# Altium schematic sheet Multiplexage_Matrices.SchDoc
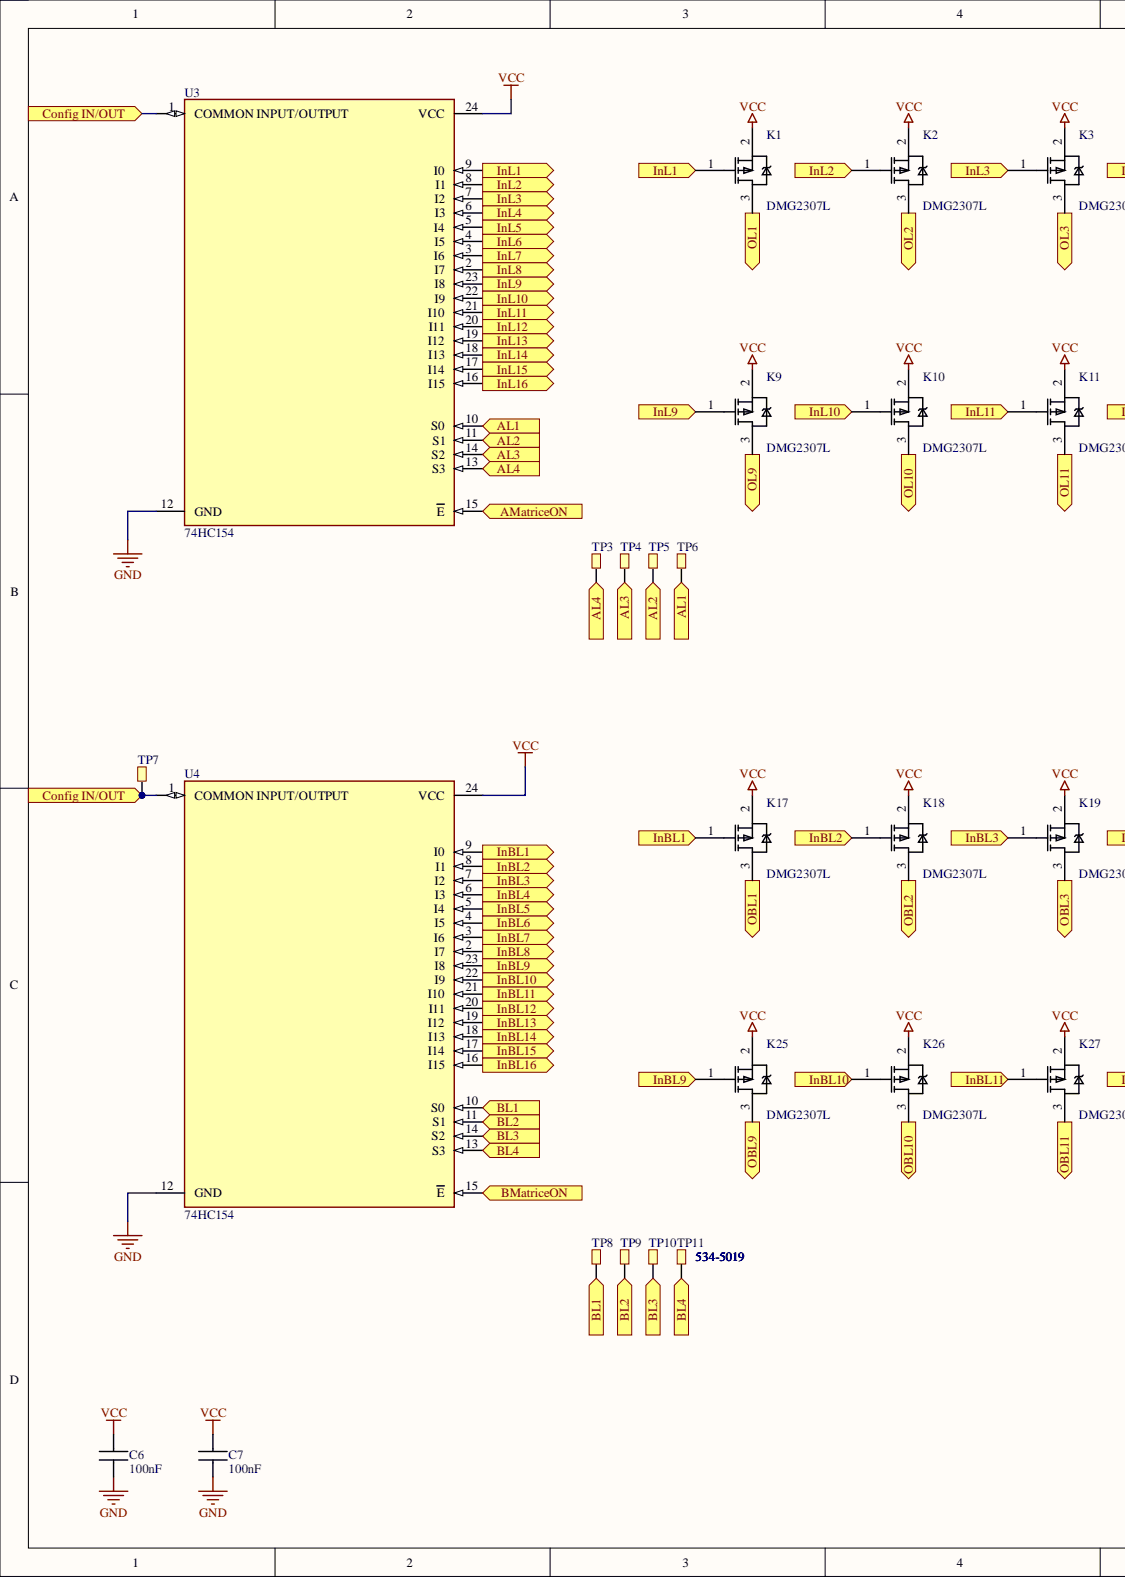
[diagram p0: Multiplexage_Matrices.SchDoc, left]
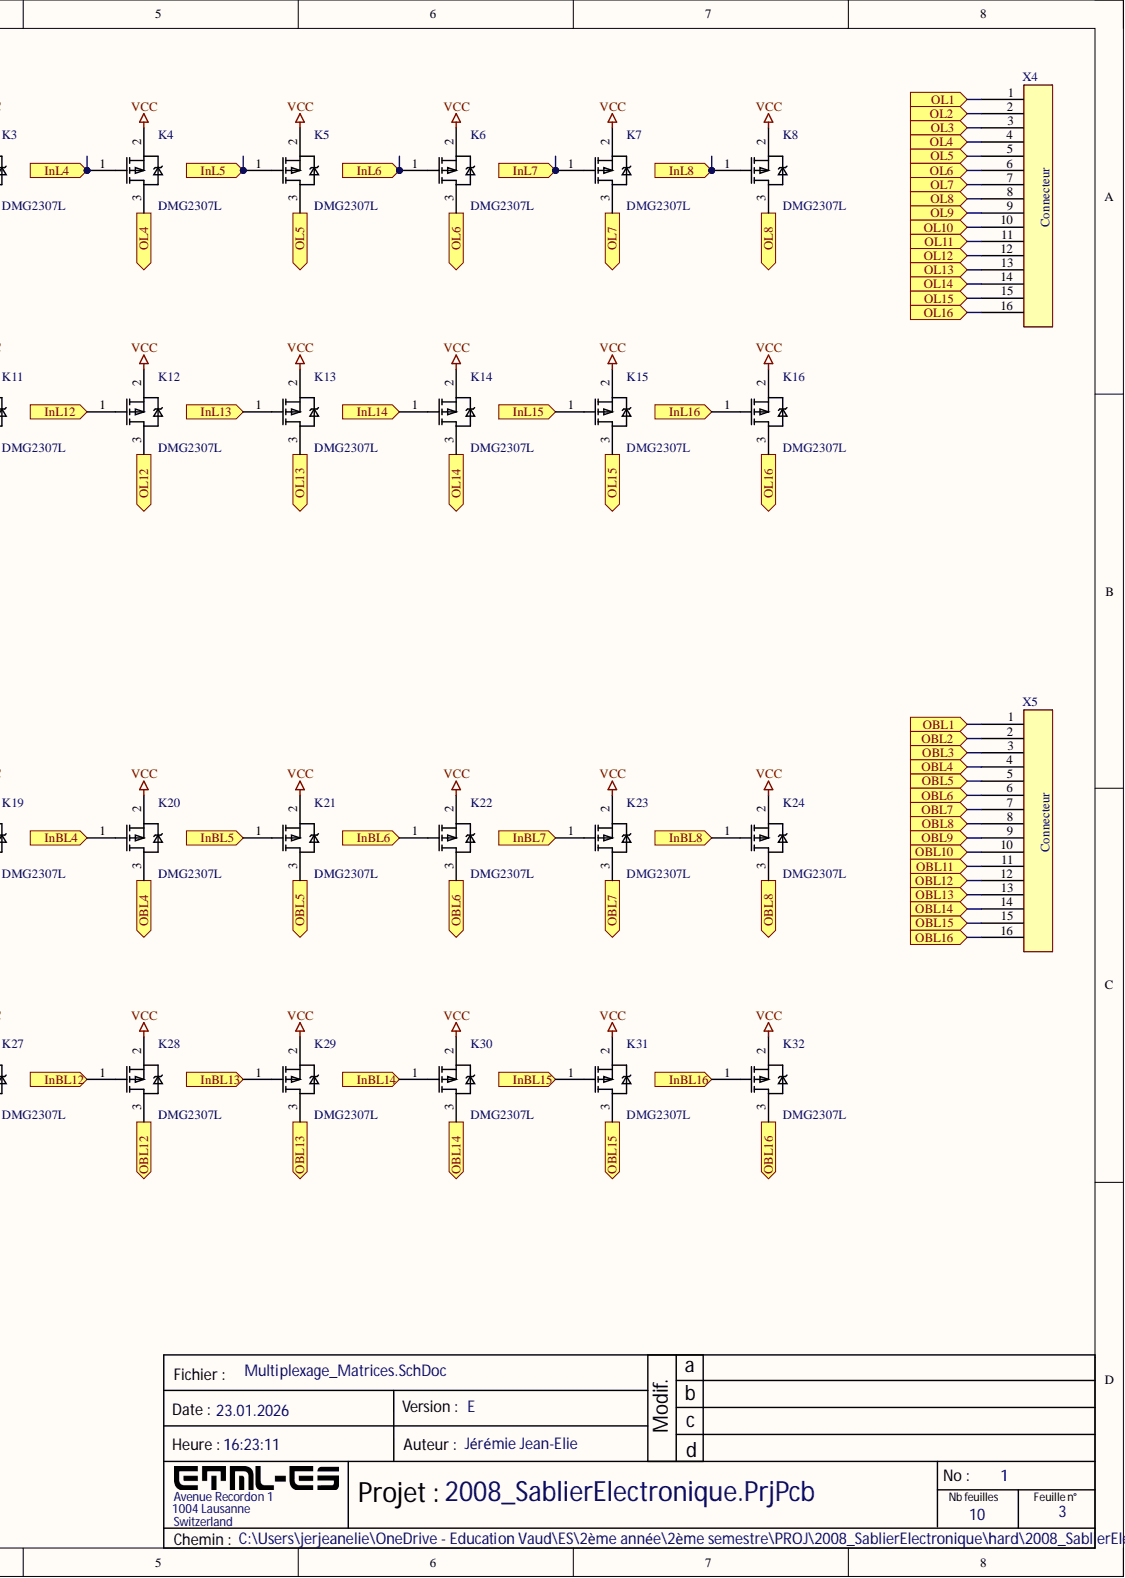
[diagram p1: Multiplexage_Matrices.SchDoc, right]

## Parts
C6  100nF  [CAPC1608X08M]
C7  100nF  [CAPC1608X08M]
K1  DMG2307L  [SOT90P240X110-3M]
K2  DMG2307L  [SOT90P240X110-3M]
K3  DMG2307L  [SOT90P240X110-3M]
K4  DMG2307L  [SOT90P240X110-3M]
K5  DMG2307L  [SOT90P240X110-3M]
K6  DMG2307L  [SOT90P240X110-3M]
K7  DMG2307L  [SOT90P240X110-3M]
K8  DMG2307L  [SOT90P240X110-3M]
K9  DMG2307L  [SOT90P240X110-3M]
K10  DMG2307L  [SOT90P240X110-3M]
K11  DMG2307L  [SOT90P240X110-3M]
K12  DMG2307L  [SOT90P240X110-3M]
K13  DMG2307L  [SOT90P240X110-3M]
K14  DMG2307L  [SOT90P240X110-3M]
K15  DMG2307L  [SOT90P240X110-3M]
K16  DMG2307L  [SOT90P240X110-3M]
K17  DMG2307L  [SOT90P240X110-3M]
K18  DMG2307L  [SOT90P240X110-3M]
K19  DMG2307L  [SOT90P240X110-3M]
K20  DMG2307L  [SOT90P240X110-3M]
K21  DMG2307L  [SOT90P240X110-3M]
K22  DMG2307L  [SOT90P240X110-3M]
K23  DMG2307L  [SOT90P240X110-3M]
K24  DMG2307L  [SOT90P240X110-3M]
K25  DMG2307L  [SOT90P240X110-3M]
K26  DMG2307L  [SOT90P240X110-3M]
K27  DMG2307L  [SOT90P240X110-3M]
K28  DMG2307L  [SOT90P240X110-3M]
K29  DMG2307L  [SOT90P240X110-3M]
K30  DMG2307L  [SOT90P240X110-3M]
K31  DMG2307L  [SOT90P240X110-3M]
K32  DMG2307L  [SOT90P240X110-3M]
TP3  5019  [TESTPOINT_SMD]  (value not drawn)
TP4  5019  [TESTPOINT_SMD]  (value not drawn)
TP5  5019  [TESTPOINT_SMD]  (value not drawn)
TP6  5019  [TESTPOINT_SMD]  (value not drawn)
TP7  5019  [TESTPOINT_SMD]  (value not drawn)
TP8  5019  [TESTPOINT_SMD]  (value not drawn)
TP9  5019  [TESTPOINT_SMD]  (value not drawn)
TP10  5019  [TESTPOINT_SMD]  (value not drawn)
TP11  5019  Supplier Part Number 1: 534-5019  [TESTPOINT_SMD]
U3  74HC154  [SOIC24]
U4  74HC154  [SOIC24]
X4  (no value)  [CON8x8(edited)]
X5  (no value)  [CON8x8(edited)]

## Ports
AL1, AL2, AL3, AL4, AMatriceON, BL1, BL2, BL3, BL4, BMatriceON, Config IN/OUT, InBL1, InBL2, InBL3, InBL4, InBL5, InBL6, InBL7, InBL8, InBL9, InBL10, InBL11, InBL12, InBL13, InBL14, InBL15, InBL16, InL1, InL2, InL3, InL4, InL5, InL6, InL7, InL8, InL9, InL10, InL11, InL12, InL13, InL14, InL15, InL16, OBL1, OBL2, OBL3, OBL4, OBL5, OBL6, OBL7, OBL8, OBL9, OBL10, OBL11, OBL12, OBL13, OBL14, OBL15, OBL16, OL1, OL2, OL3, OL4, OL5, OL6, OL7, OL8, OL9, OL10, OL11, OL12, OL13, OL14, OL15, OL16

## Nets
GND: C6.1 C7.1 U3.12(GND) U4.12(GND)
VCC: C6.2 C7.2 K1.2 K2.2 K3.2 K4.2 K5.2 K6.2 K7.2 K8.2 K9.2 K10.2 K11.2 K12.2 K13.2 K14.2 K15.2 K16.2 K17.2 K18.2 K19.2 K20.2 K21.2 K22.2 K23.2 K24.2 K25.2 K26.2 K27.2 K28.2 K29.2 K30.2 K31.2 K32.2 U3.24(VCC) U4.24(VCC)
AL1: TP6.1 U3.10(S0) ; port AL1
AL2: TP5.1 U3.11(S1) ; port AL2
AL3: TP4.1 U3.14(S2) ; port AL3
AL4: TP3.1 U3.13(S3) ; port AL4
AMatriceON: U3.15(E) ; port AMatriceON
BL1: TP8.1 U4.10(S0) ; port BL1
BL2: TP9.1 U4.11(S1) ; port BL2
BL3: TP10.1 U4.14(S2) ; port BL3
BL4: TP11.1 U4.13(S3) ; port BL4
BMatriceON: U4.15(E) ; port BMatriceON
Config IN/OUT: TP7.1 U3.1(COMMON_INPUT/OUTPUT) U4.1(COMMON_INPUT/OUTPUT) ; port Config IN/OUT
InBL1: K17.1 U4.9(I0) ; port InBL1
InBL2: K18.1 U4.8(I1) ; port InBL2
InBL3: K19.1 U4.7(I2) ; port InBL3
InBL4: K20.1 U4.6(I3) ; port InBL4
InBL5: K21.1 U4.5(I4) ; port InBL5
InBL6: K22.1 U4.4(I5) ; port InBL6
InBL7: K23.1 U4.3(I6) ; port InBL7
InBL8: K24.1 U4.2(I7) ; port InBL8
InBL9: K25.1 U4.23(I8) ; port InBL9
InBL10: K26.1 U4.22(I9) ; port InBL10
InBL11: K27.1 U4.21(I10) ; port InBL11
InBL12: K28.1 U4.20(I11) ; port InBL12
InBL13: K29.1 U4.19(I12) ; port InBL13
InBL14: K30.1 U4.18(I13) ; port InBL14
InBL15: K31.1 U4.17(I14) ; port InBL15
InBL16: K32.1 U4.16(I15) ; port InBL16
InL1: K1.1 U3.9(I0) ; port InL1
InL2: K2.1 U3.8(I1) ; port InL2
InL3: K3.1 U3.7(I2) ; port InL3
InL4: K4.1 U3.6(I3) ; port InL4
InL5: K5.1 U3.5(I4) ; port InL5
InL6: K6.1 U3.4(I5) ; port InL6
InL7: K7.1 U3.3(I6) ; port InL7
InL8: K8.1 U3.2(I7) ; port InL8
InL9: K9.1 U3.23(I8) ; port InL9
InL10: K10.1 U3.22(I9) ; port InL10
InL11: K11.1 U3.21(I10) ; port InL11
InL12: K12.1 U3.20(I11) ; port InL12
InL13: K13.1 U3.19(I12) ; port InL13
InL14: K14.1 U3.18(I13) ; port InL14
InL15: K15.1 U3.17(I14) ; port InL15
InL16: K16.1 U3.16(I15) ; port InL16
OBL1: K17.3 X5.1 ; port OBL1
OBL2: K18.3 X5.2 ; port OBL2
OBL3: K19.3 X5.3 ; port OBL3
OBL4: K20.3 X5.4 ; port OBL4
OBL5: K21.3 X5.5 ; port OBL5
OBL6: K22.3 X5.6 ; port OBL6
OBL7: K23.3 X5.7 ; port OBL7
OBL8: K24.3 X5.8 ; port OBL8
OBL9: K25.3 X5.9 ; port OBL9
OBL10: K26.3 X5.10 ; port OBL10
OBL11: K27.3 X5.11 ; port OBL11
OBL12: K28.3 X5.12 ; port OBL12
OBL13: K29.3 X5.13 ; port OBL13
OBL14: K30.3 X5.14 ; port OBL14
OBL15: K31.3 X5.15 ; port OBL15
OBL16: K32.3 X5.16 ; port OBL16
OL1: K1.3 X4.1 ; port OL1
OL2: K2.3 X4.2 ; port OL2
OL3: K3.3 X4.3 ; port OL3
OL4: K4.3 X4.4 ; port OL4
OL5: K5.3 X4.5 ; port OL5
OL6: K6.3 X4.6 ; port OL6
OL7: K7.3 X4.7 ; port OL7
OL8: K8.3 X4.8 ; port OL8
OL9: K9.3 X4.9 ; port OL9
OL10: K10.3 X4.10 ; port OL10
OL11: K11.3 X4.11 ; port OL11
OL12: K12.3 X4.12 ; port OL12
OL13: K13.3 X4.13 ; port OL13
OL14: K14.3 X4.14 ; port OL14
OL15: K15.3 X4.15 ; port OL15
OL16: K16.3 X4.16 ; port OL16

## Library data of the parts
C6 C7 = CMP-065-000000-2: CAPC 100nF X7R 50V 10% 1608 (0603 INCH); Manufacturer: TDK; Manufacturer Number: CGA3E2X7R1H104K080AA; Current: NULL; IsStocked: 1; PN: PN-338083; TemperatureRange: -55°C to +125°C; Category: Passives; Component Kind: Standard; Dielectric: X7R; Library Name: ETML-ES; Power: NULL; SubCategory: Condensateurs ceramique; Supplier 1: ETML; Supplier Part Number 1: FAB.0603_C 100n; Supplier Price 1: 0.0100; TemperatureCoeff: NULL; Tolerance: ±10%; Value: 100nF; Voltage: 50V
K1-K32 = CMP-056-000002-3: TRAN DMG2307L P-Channel Enhancement MOSFET 30V 2.5A; Manufacturer: Diodes Incorporated; IsStocked: 0; PN: PN-317407; Power: 760mW; TemperatureRange: -55°C to +150°C; Category: DiscreteSemiconductors; Component Kind: Standard; Current: 2.5A; Library Name: ETML-ES; Manufacturer Number: DMG2307L; SubCategory: Transistors; Supplier 1: Farnell; Supplier Part Number 1: 2061518; Supplier Price 1: 0.1060; Value: DMG2307L; Voltage: 30V
TP3-TP11 = TESTPOINT: Test point smd; Component Kind: Standard; Supplier 1: Mouser; Library Name: 2008_SablierElectronique_SCHLIB.SchLib
  TP3-TP10: Supplier Part Number 1: 534-5019
U3 U4 = CD74HCT4067: Component Kind: Standard; Supplier 1: Mouser; Supplier Part Number 1: 595-CD74HC4067M; Library Name: 2008_SablierElectronique_SCHLIB.SchLib
X4 X5 = Mâle DIN 8 x 8: Component Kind: Standard; Library Name: 2008_SablierElectronique_SCHLIB.SchLib
